# Altium schematic sheet USB_FS.SchDoc(USB_FS)
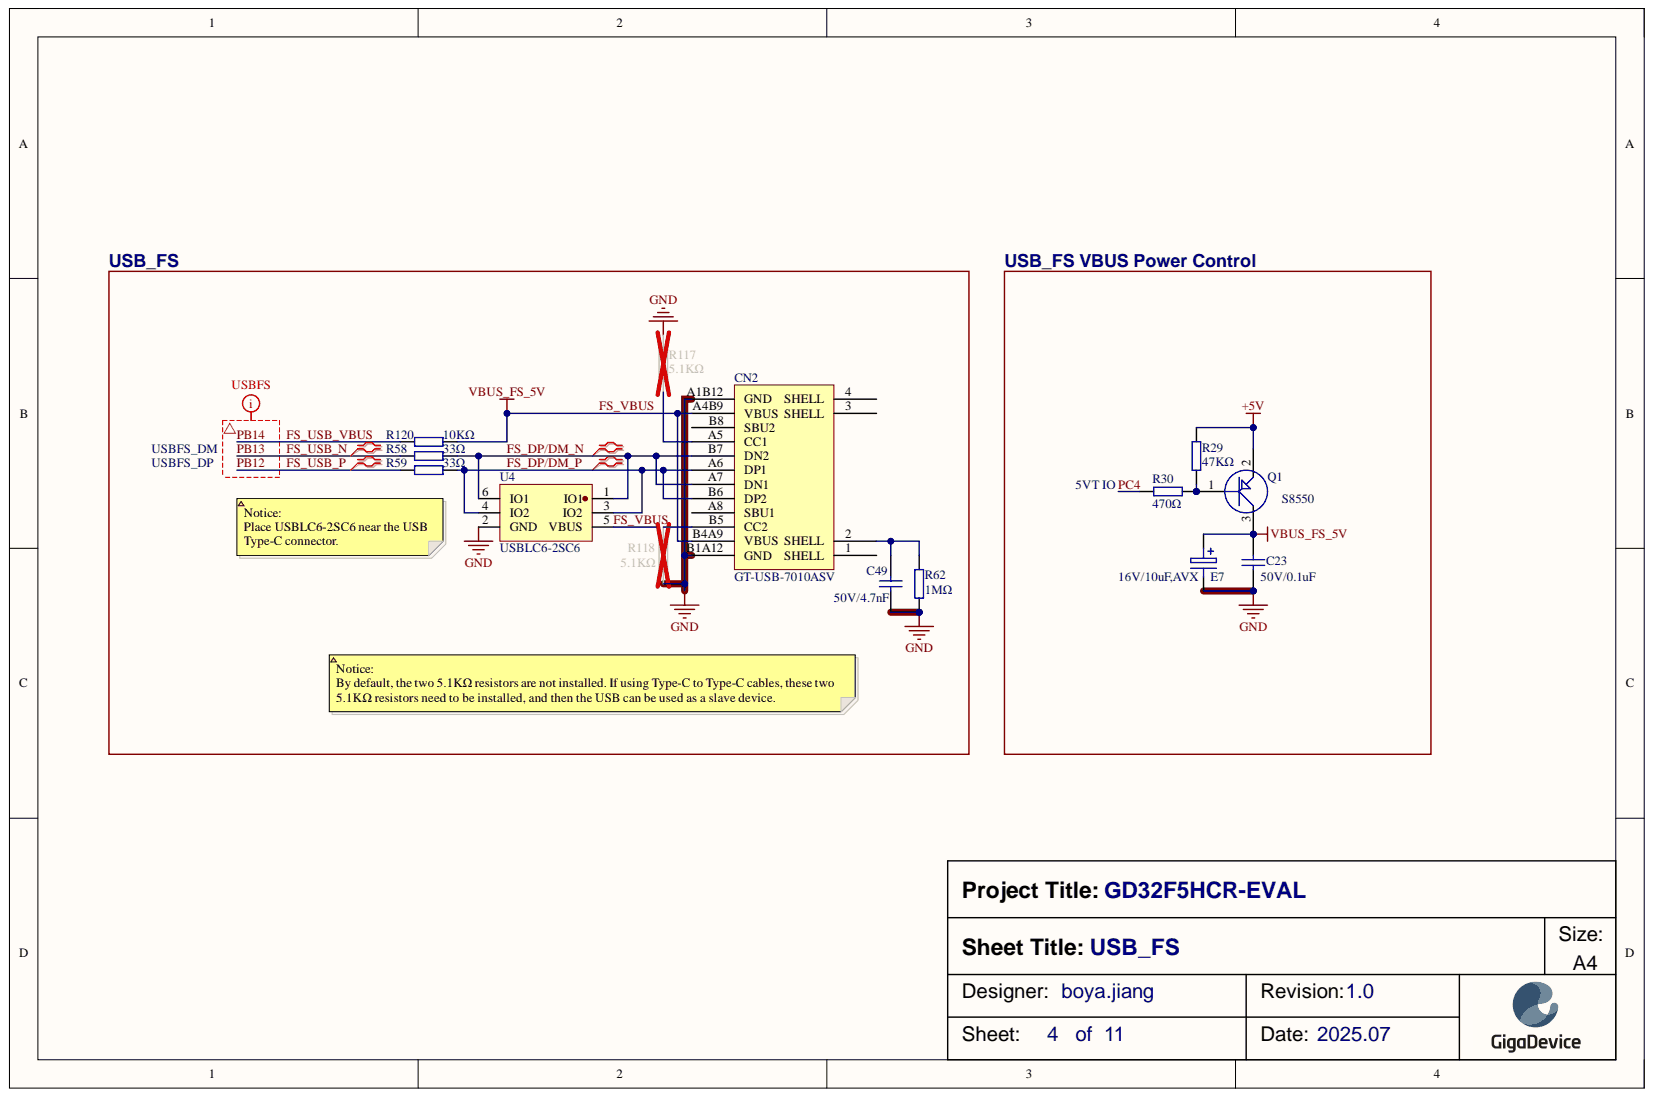
[diagram p0: USB_FS.SchDoc]

## Parts
C23  50V/0.1uF  [0603-C]
C49  50V/4.7nF  [0603-C]
CN2  GT-USB-7010ASV  [USB-C-16Pin_GT-USB-7010ASV]
E7  16V/10uF,AVX  [SM/C-A-3216]
Q1  S8550  [SOT-23]
R29  47KΩ  [0603-R]
R30  470Ω  [0603-R]
R58  33Ω  [0603-R]
R59  33Ω  [0603-R]
R62  1MΩ  [0603-R]
R117  5.1KΩ  [0603-R]  (no BOM)
R118  5.1KΩ  [0603-R]  (no BOM)
R120  10KΩ  [0603-R]
U4  USBLC6-2SC6  [SOT-23-6]

## Nets
+5V: Q1.2 R29.2
GND: C23.2 C49.1 CN2.A1B12(GND) CN2.B1A12(GND) E7.2 R62.1 R117.2 R118.1 U4.2(GND)
FS_DP/DM_N: CN2.A7(DN1) CN2.B7(DN2) R58.2 U4.1(IO1) U4.6(IO1)
FS_DP/DM_P: CN2.A6(DP1) CN2.B6(DP2) R59.2 U4.3(IO2) U4.4(IO2)
FS_USB_N: R58.1
FS_USB_P: R59.1
FS_USB_VBUS: R120.1
FS_VBUS: C23.1 CN2.A4B9(VBUS) CN2.B4A9(VBUS) E7.1 Q1.3 R120.2 U4.5(VBUS)
PC4: R30.1
NetC49_2: C49.2 CN2.2(SHELL) R62.2
NetCN2_A5: CN2.A5(CC1) R117.1
NetCN2_B5: CN2.B5(CC2) R118.2
NetQ1_1: Q1.1 R29.1 R30.2

Not connected: CN2 1(SHELL) 3(SHELL) 4(SHELL) A8(SBU1) B8(SBU2)

## Library data of the parts
C23 = 50V/0.01uF: 表贴电容; Component Kind: Standard; Library Name: KLTC-电容.SchLib
C49 = 50V/4700pF: 表贴电容; Component Kind: Standard; Library Name: KLTC-电容.SchLib
CN2 = USB-C: 表贴USB2.0 TYPE-C接口，16PIN; Component Kind: Standard; Library Name: boyajiang.SchLib
E7 = 16V/10uF,AVX: 表贴钽电容; Component Kind: Standard; Library Name: KLTC-电容.SchLib
Q1 = 9012(MMBT9012LT): 表贴三极管; Component Kind: Standard; Library Name: KLTC-二三极管.SchLib
R29 R30 R58 R59 R120 = 1KΩ: 表贴电阻; Component Kind: Standard; Library Name: KLTC-电阻.SchLib
R62 R117 R118 = 1MΩ: 表贴电阻; Library Name: KLTC-电阻.SchLib
  R62: Component Kind: Standard
U4 = USBLC6-2SC6: 低结电容TVS阵列，可用于USB2.0接口防护; Note: 不焊; Component Kind: Standard; Library Name: boyajiang.SchLib
